# Altium schematic sheet IRSensor.SchDoc
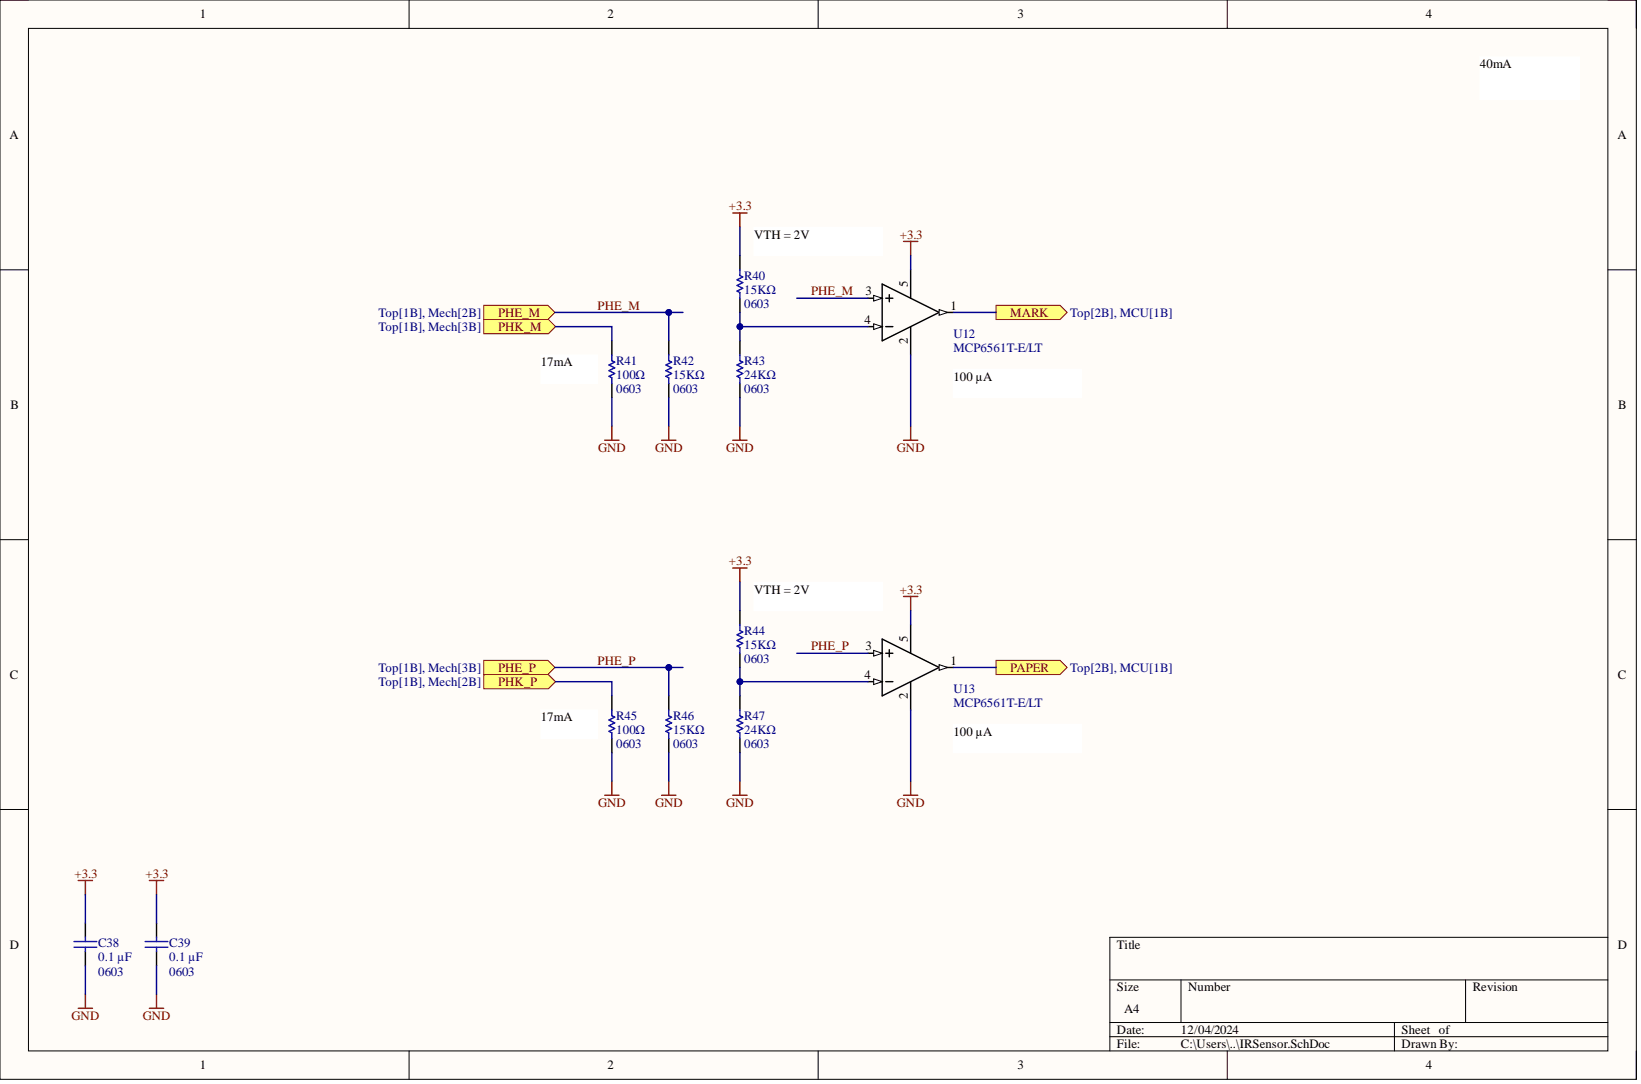
[diagram p0: IRSensor.SchDoc]

## Parts
C38  value 0.1 µF  [0603]  (hidden comment: GRM188R72A104MA35J)
C39  value 0.1 µF  [0603]  (hidden comment: GRM188R72A104MA35J)
R40  value 15KΩ  [0603]  (hidden comment: RMCF0603FG15K0)
R41  value 100Ω  [0603]  (hidden comment: RMCF0603FG100R)
R42  value 15KΩ  [0603]  (hidden comment: RMCF0603FG15K0)
R43  value 24KΩ  [0603]  (hidden comment: RMCF0603FG24K0)
R44  value 15KΩ  [0603]  (hidden comment: RMCF0603FG15K0)
R45  value 100Ω  [0603]  (hidden comment: RMCF0603FG100R)
R46  value 15KΩ  [0603]  (hidden comment: RMCF0603FG15K0)
R47  value 24KΩ  [0603]  (hidden comment: RMCF0603FG24K0)
U12  MCP6561T-E/LT  [SC-70-5]
U13  MCP6561T-E/LT  [SC-70-5]

## Ports
MARK, PAPER, PHE_M, PHE_P, PHK_M, PHK_P

## Nets
+3.3: C38.1 C39.1 R40.2 R44.2 U12.5 U13.5
GND: C38.2 C39.2 R41.1 R42.1 R43.1 R45.1 R46.1 R47.1 U12.2 U13.2
MARK: U12.1 ; port MARK
PAPER: U13.1 ; port PAPER
PHE_M: R42.2 U12.3 ; port PHE_M
PHE_P: R46.2 U13.3 ; port PHE_P
PHK_M: R41.2 ; port PHK_M
PHK_P: R45.2 ; port PHK_P
NetR40_1: R40.1 R43.2 U12.4
NetR44_1: R44.1 R47.2 U13.4

## Library data of the parts
C38 C39 = GRM188R72A104MA35J: 0.1 µF ±20% 100V Ceramic Capacitor X7R 0603 (1608 Metric); Case: 0603; Component Kind: Standard; Library Name: Murata Electronics.IntLib; Temperature Coefficient: X7R; Tolerance: ±20%; Voltage: 100V
R40 R42 R44 R46 = RMCF0603FG15K0: 15 kOhms ±1% 0.1W, 1/10W Chip Resistor 0603 (1608 Metric) Automotive AEC-Q200 Thick Film; Case: 0603; Component Kind: Standard; Power: 0.1W; Tolerance: 1%; Library Name: Stackpole Electronics Inc.IntLib
R41 R45 = RMCF0603FG100R: 100 Ohms ±1% 0.1W, 1/10W Chip Resistor 0603 (1608 Metric) Automotive AEC-Q200 Thick Film; Case: 0603; Component Kind: Standard; Power: 0.1W; Tolerance: 1%; Library Name: Stackpole Electronics Inc.IntLib
R43 R47 = RMCF0603FG24K0: 24 kOhms ±1% 0.1W, 1/10W Chip Resistor 0603 (1608 Metric) Automotive AEC-Q200 Thick Film; Case: 0603; Component Kind: Standard; Power: 0.1W; Tolerance: 1%; Library Name: Stackpole Electronics Inc.IntLib
U12 U13 = MCP6561T-E/LT: Comparator General Purpose CMOS, Push-Pull, Rail-to-Rail, TTL SC-70-5; Component Kind: Standard; Library Name: Microchip Technology.IntLib
